# Altium schematic sheet PIN(13~14).SchDoc(PIN(13~14))
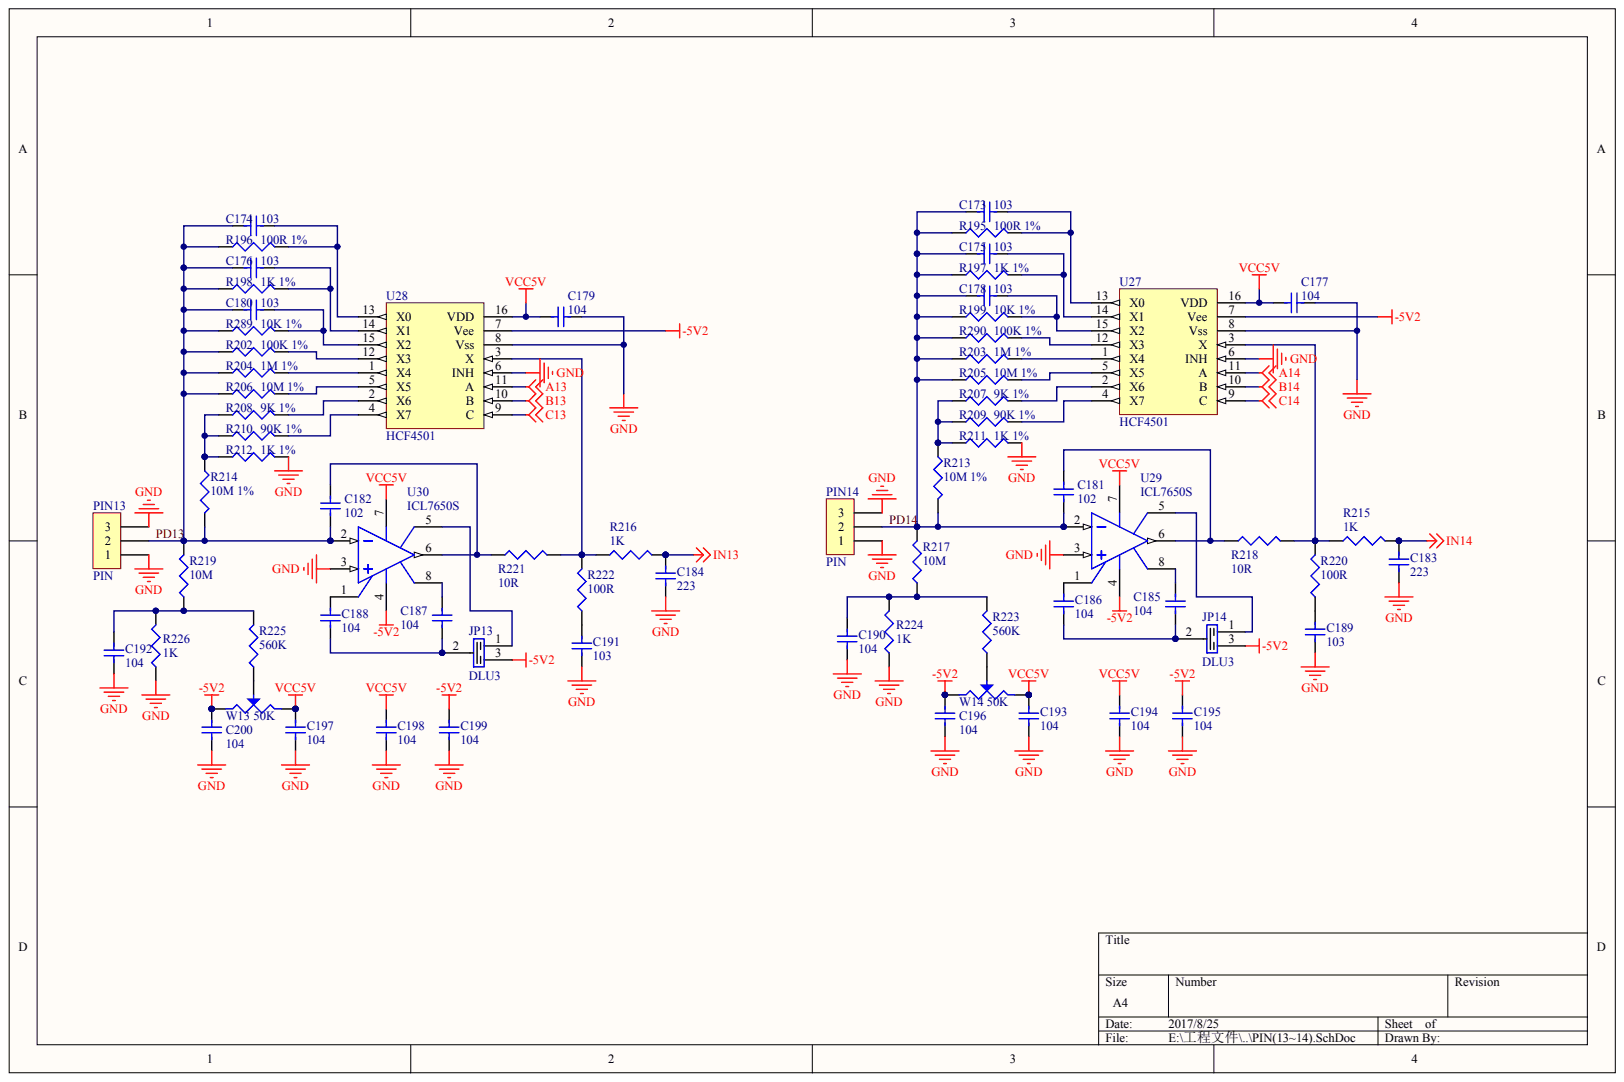
[diagram p0: PIN]

## Parts
C173  103
C174  103
C175  103
C176  103
C177  104
C178  103
C179  104
C180  103
C181  102
C182  102
C183  223
C184  223
C185  104
C186  104
C187  104
C188  104
C189  103
C190  104
C191  103
C192  104
C193  104
C194  104
C195  104
C196  104
C197  104
C198  104
C199  104
C200  104
JP13  DLU3
JP14  DLU3
PIN13  PIN
PIN14  PIN
R195  100R 1%
R196  100R 1%
R197  1K 1%
R198  1K 1%
R199  10K 1%
R202  100K 1%
R203  1M 1%
R204  1M 1%
R205  10M 1%
R206  10M 1%
R207  9K 1%
R208  9K 1%
R209  90K 1%
R210  90K 1%
R211  1K 1%
R212  1K 1%
R213  10M 1%
R214  10M 1%
R215  1K
R216  1K
R217  10M
R218  10R
R219  10M
R220  100R
R221  10R
R222  100R
R223  560K
R224  1K
R225  560K
R226  1K
R289  10K 1%
R290  100K 1%
U27  HCF4501
U28  HCF4501
U29  ICL7650S
U30  ICL7650S
W13  50K
W14  50K

## Nets
-5V2: C195.2 C196.1 C199.2 C200.1 JP13.3 JP14.3 U27.7(Vee) U28.7(Vee) U29.4 U30.4 W13.1 W14.1
A13: U28.11(A)
A14: U27.11(A)
B13: U28.10(B)
B14: U27.10(B)
C13: U28.9(C)
C14: U27.9(C)
GND: C177.2 C179.2 C183.1 C184.1 C189.1 C190.1 C191.1 C192.1 C193.1 C194.1 C195.1 C196.2 C197.1 C198.1 C199.1 C200.2 PIN13.1 PIN13.3 PIN14.1 PIN14.3 R211.2 R212.2 R224.1 R226.1 U27.6(INH) U27.8(Vss) U28.6(INH) U28.8(Vss) U29.3 U30.3
IN13: C184.2 R216.2
IN14: C183.2 R215.2
VCC5V: C177.1 C179.1 C193.2 C194.2 C197.2 C198.2 U27.16(VDD) U28.16(VDD) U29.7 U30.7 W13.3 W14.3
PD13: C174.1 C176.1 C180.1 C182.1 PIN13.2 R196.1 R198.1 R202.1 R204.1 R206.1 R214.2 R219.2 R289.1 U30.2
PD14: C173.1 C175.1 C178.1 C181.1 PIN14.2 R195.1 R197.1 R199.1 R203.1 R205.1 R213.2 R217.2 R290.1 U29.2
NetC173_2: C173.2 R195.2 U27.13(X0)
NetC174_2: C174.2 R196.2 U28.13(X0)
NetC175_2: C175.2 R197.2 U27.14(X1)
NetC176_2: C176.2 R198.2 U28.14(X1)
NetC178_2: C178.2 R199.2 U27.15(X2)
NetC180_2: C180.2 R289.2 U28.15(X2)
NetC181_2: C181.2 R218.1 U29.6
NetC182_2: C182.2 R221.1 U30.6
NetC185_1: C185.1 U29.8
NetC185_2: C185.2 C186.1 JP14.2
NetC186_2: C186.2 U29.1
NetC187_1: C187.1 U30.8
NetC187_2: C187.2 C188.1 JP13.2
NetC188_2: C188.2 U30.1
NetC189_2: C189.2 R220.1
NetC190_2: C190.2 R217.1 R223.2 R224.2
NetC191_2: C191.2 R222.1
NetC192_2: C192.2 R219.1 R225.2 R226.2
NetJP13_1: JP13.1 U30.5
NetJP14_1: JP14.1 U29.5
NetR202_2: R202.2 U28.12(X3)
NetR203_2: R203.2 U27.1(X4)
NetR204_2: R204.2 U28.1(X4)
NetR205_2: R205.2 U27.5(X5)
NetR206_2: R206.2 U28.5(X5)
NetR207_1: R207.1 R209.1 R211.1 R213.1
NetR207_2: R207.2 U27.2(X6)
NetR208_1: R208.1 R210.1 R212.1 R214.1
NetR208_2: R208.2 U28.2(X6)
NetR209_2: R209.2 U27.4(X7)
NetR210_2: R210.2 U28.4(X7)
NetR215_1: R215.1 R218.2 R220.2 U27.3(X)
NetR216_1: R216.1 R221.2 R222.2 U28.3(X)
NetR223_1: R223.1 W14.2
NetR225_1: R225.1 W13.2
NetR290_2: R290.2 U27.12(X3)
